# Altium schematic sheet SB_Main.SchDoc
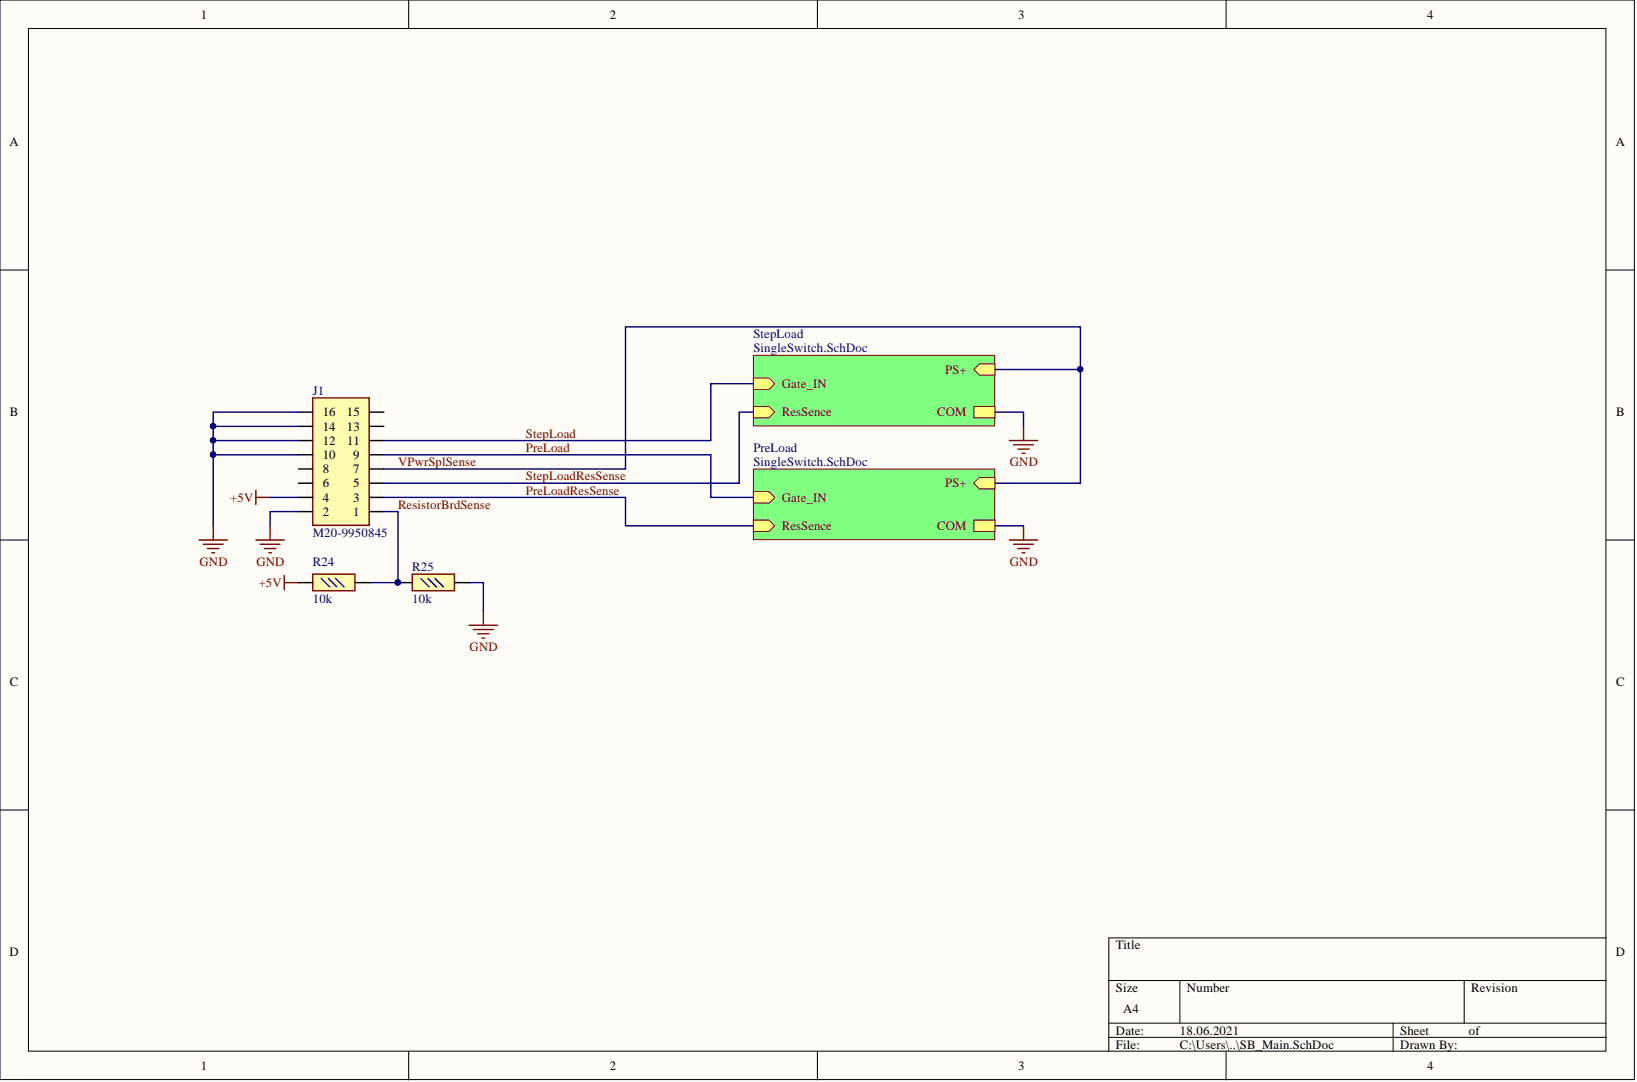
[diagram p0: SB_Main.SchDoc]

## Parts
J1  M20-9950845  [M20-9950845]
R24  10k  [0603r]
R25  10k  [0603r]

## Nets
+5V: J1.4 R24.1
GND: J1.2 J1.10 J1.12 J1.14 J1.16 R25.2
PreLoad: J1.9
PreLoadResSense: J1.3
ResistorBrdSense: J1.1 R24.2 R25.1
StepLoad: J1.11
StepLoadResSense: J1.5
VPwrSplSense: J1.7

Not connected: J1 6 8 13 15

## Library data of the parts
J1 = M20-9950845: 2 x 8pin 2.54 Male 90 deg; Company: Harwin; System: Connector; Component Kind: Standard; Mounting: TH; Order Code: M20-9950845; Supplier 1: Mouser; Supplier Part Number 1: 855-M20-9950845; Type: Connector; Value: M20-9950845; Library Name: SOEDINITELI_hOME.SchLib
R24 R25 = 10k 0603 5%: Company: Yageo; ID: B112CV1113Y; Order Code: RC0603JR-0710KL; TSKD: FS-CM_StandDet_Resistor_SMD(10K_5%_100_0603); Component Kind: Standard; Description: 10k 0603 5%; Library Name: RESISTORs_Home.SchLib; Supplier 1: Digi-Key; Supplier Part Number 1: 311-10KGRCT-ND; Type: Resistor; Value: 10k
